# Altium schematic sheet vreg.SchDoc
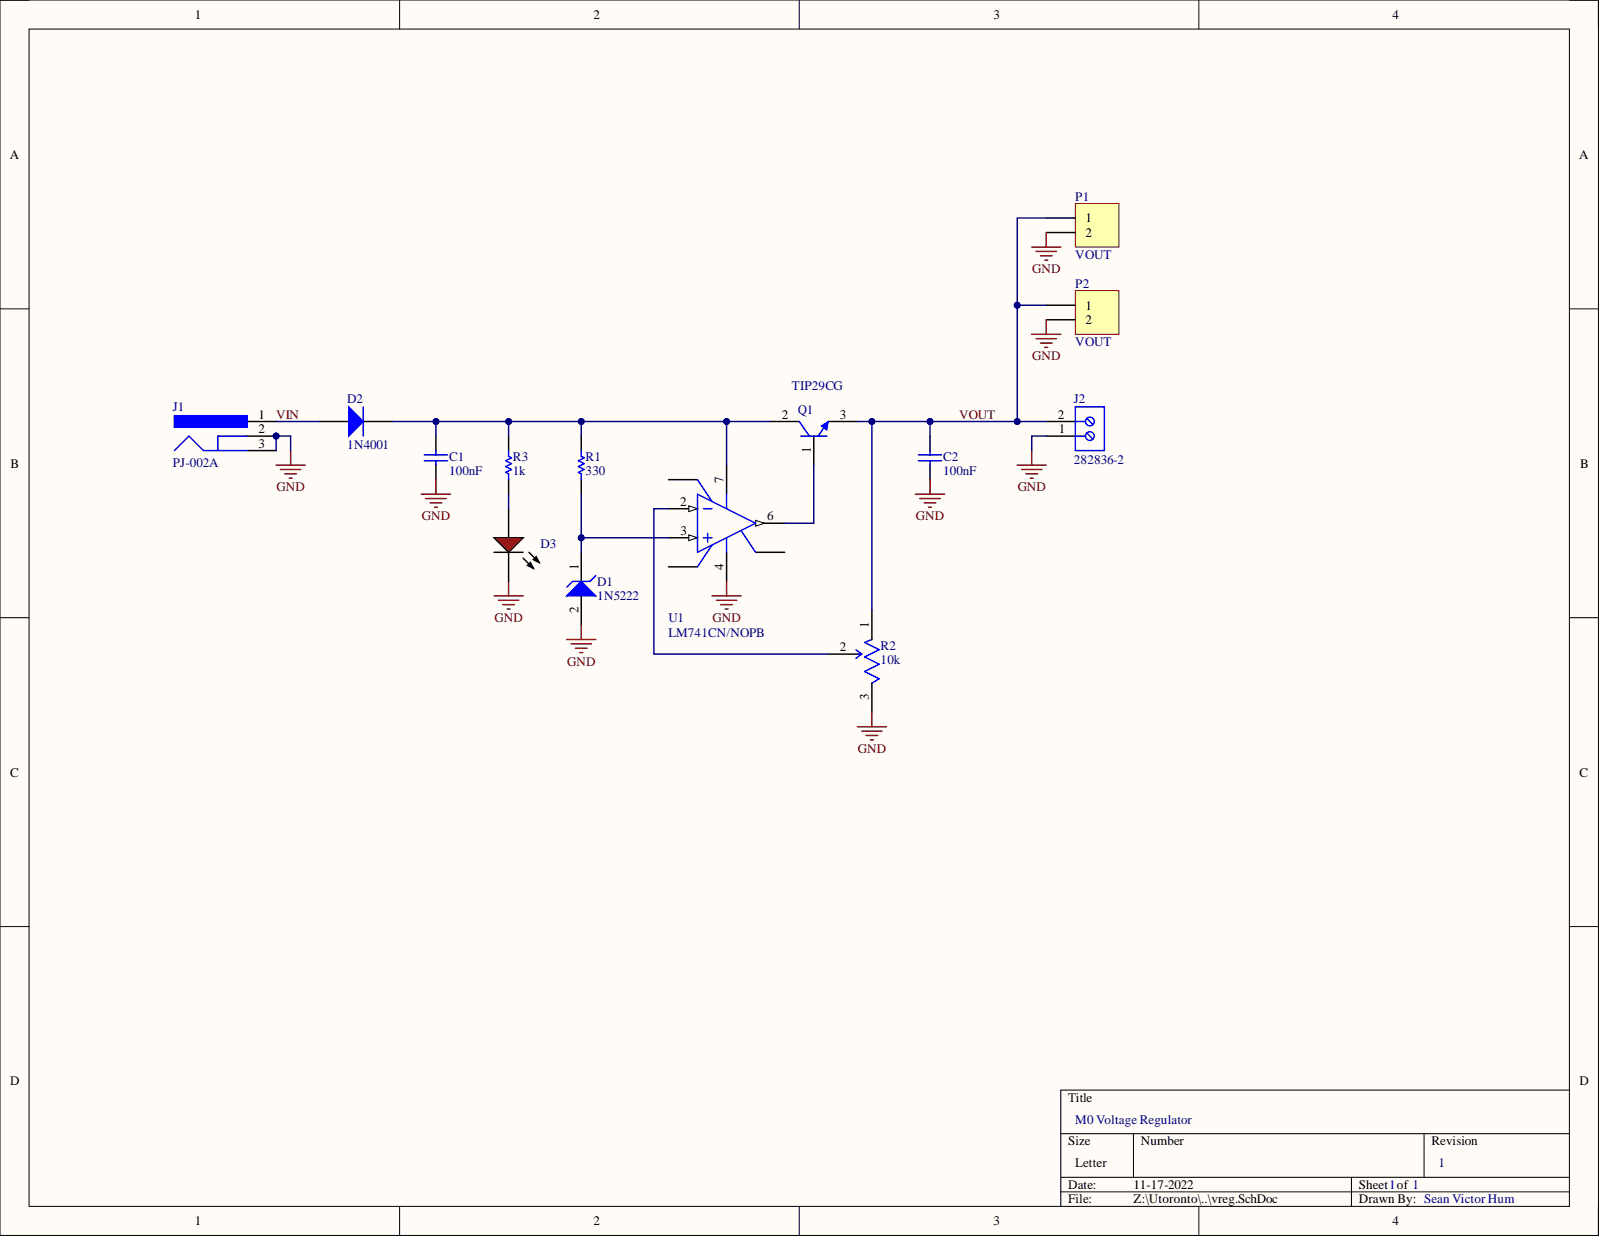
[diagram p0: vreg.SchDoc]

## Parts
C1  value 100nF  [RAD-0.1]  (hidden comment: Cap)
C2  value 100nF  [RAD-0.1]  (hidden comment: Cap)
D1  1N5222  [ONSC-SURMETIC40-2-017AA-01]
D2  1N4001  [DO-41]
D3  HLMP-3301  [AVAG-HLMP-3301-2]  (value not drawn)
J1  PJ-002A  [CUI-PJ-002A_V]
J2  282836-2  [TECO-282836-2_V]
P1  VOUT  [HDR1X2]
P2  VOUT  [HDR1X2]
Q1  TIP29CG  [ONSC-TO-220-3-221A-09]
R1  value 330  [RAD-0.1]  (hidden comment: Res1)
R2  10k  [FP-PV37W103C01B00-MFG]
R3  value 1k  [AXIAL-0.4]  (hidden comment: Res1)
U1  LM741CN/NOPB  [P0008A]

## Nets
GND: C1.2 C2.2 D1.2 D3.1 J1.2 J1.3 J2.1 P1.2 P2.2 R2.3 U1.4
VIN: D2.1 J1.1
VOUT: C2.1 J2.2 P1.1 P2.1 Q1.3 R2.1
NetC1_1: C1.1 D2.2 Q1.2 R1.2 R3.2 U1.7
NetD1_1: D1.1 R1.1 U1.3
NetD3_2: D3.2 R3.1
NetQ1_1: Q1.1 U1.6
NetR2_2: R2.2 U1.2

Not connected: U1 1 5 8

## Library data of the parts
C1 C2 = Cap: Capacitor; PartNumber: 399-4151-ND; Component Kind: Standard; LatestRevisionDate: 17-Jul-2002; LatestRevisionNote: Re-released for DXP Platform.; Library Name: Miscellaneous Devices.IntLib; PackageDescription: Radial Cap, Thru-Hole; 2 Leads; 0.3 in Pin Spacing; PackageReference: RAD-0.3; Published: 8-Jun-2000; Signal Integrity: Cap; Simulation: CAP; Publisher: Altium Limited
D1 = CMP-1060-01319-1: 5 W Zener Voltage Regulator, 2-Pin Surmetic(TM) 40, Pb-Free, Tape and Reel; Manufacturer: On Semiconductor; PartNumber: 1N5222B-ND; P Max (W): 5; VZ Typ (V): 160; Component Kind: Standard; ComponentLink1Description: Manufacturer URL; ComponentLink1URL: http://www.onsemi.com/; ComponentLink2Description: Datasheet; ComponentLink2URL: http://www.onsemi.cn/pub_link/Collateral/1N5333B-D.PDF; ComponentLink3Description: Package Specification; ComponentLink3URL: http://www.onsemi.cn/pub_link/Collateral/017AA.PDF; DatasheetVersion: Rev. 14; Library Name: Altium Content Vault; Mounting Technology: Through Hole; PackageDescription: 2-Pin, Axial Lead, Diameter 3.49 mm; PackageReference: SURMETIC40-2-017AA-01; PackageVersion: Rev. O, 09/2005; Packing: Tape and Reel; RoHS: Pb-Free
D2 = Diode 1N4001: 1 Amp General Purpose Rectifier; PartNumber: S1011EC-04-ND; Code_JEDEC: DO-204-AL; Component Kind: Standard; ComponentLink1Description: Manufacturer Link; ComponentLink1URL: http://www.fairchildsemi.com/; ComponentLink2Description: Datasheet; ComponentLink2URL: http://www.fairchildsemi.com/ds/1N%2F1N4001.pdf; DatasheetVersion: 1998; LatestRevisionDate: 17-Jul-2002; LatestRevisionNote: Re-released for DXP Platform.; Library Name: Miscellaneous Devices.IntLib; PackageDescription: Diode, Axial; Body 5.2 x 2.7 mm (LxDia. max), Lead Dia. 0.86 mm (max); PackageReference: DO-41; PackageVersion: Aug-1999; Published: 8-Jun-2000; Signal Integrity: 1N4001; Simulation: 1N4001; Publisher: Altium Limited
D3 = CMP-2000-06411-1: T-1 3/4 (5 mm) Diffused LED Lamp, 1.9 V, -40 to 100 degC, 2-Pin THD, RoHS, Bulk; Manufacturer: Avago; PartNumber: 1497-XCMDK12D-ND; Chip Material: GaP; DELETED: Compliant; Dominant Wave Length: 626nm; Emitting Color: Red; Forward Current: 25mA; Forward Voltage: 2.4V; High Brightness: No; Lens Type: ColoredDiffused; Lum Intensity: 5.4mcd; Main Category: StandardLED; Number of  Elements: 1; Peak Wavelength: 635nm; Power Dissipation: 85W; Product Depth (mm): 6.1mm; Product Height (mm): 9.19mm; Reverse Voltage: 5V; Shape Type: Circular; Test Current (It): 10mA; Type: Uni-Color; Viewing Angle: 60deg; Component Kind: Standard; Datasheet URL: http://www.avagotech.com/docs/AV02-1558EN; Datasheet Version: 03/2015; Lens Dimensions: 5.08x5.08x8.3mm; Library Name: Altium Content Vault; Luminous Intensity: 5.4mcd; Manufacturer URL: http://www.avagotech.com/; Mounting: ThroughHole; Mounting Technology: ThroughHole; Operating Temp Range: -40Cto100C; Operating Temperature Classification: Industrial; Package Description: 2-Pin Through Hole LED, Dia 5.85 mm; Package Reference: HLMP-3301; Package Type: T-13/4; Packaging: TapeandReel; Pin Count: 2; Product Length (mm): 6.1mm; Rad Hardened: No
J1 = CMP-2000-06895-1: Through Hole Right Angle DC Power Jack, 2.5 A, 2 mm Center Pin, 3 Position, -25 to 85 degC, RoHS, Bulk; Manufacturer: CUIInc.; PartNumber: CP-002A-ND; Brand: CUI; Current Rating: 2.5A; Inside Contact Diameter: 2mm; Insulation Resistance: 50MOhms; Outside Contact Diameter: 6.5mm; Voltage Rating: 24V; Component Kind: Standard; Connector Type: DCPowerJack; Contact Gender: Male; Contact Material: Copper; Contact Plating: Nickel; Datasheet URL: http://www.cui.com/product/resource/pj-002a.pdf; Datasheet Version: Rev. 1.03, 04/2016; Factory Pack Quantity: 500; Flammability Rating: UL94V-0; Housing Material: PolybutyleneTerephthalate(PBT); Library Name: Altium Content Vault; Manufacturer URL: http://www.cui.com/; Mounting Angle: Right; Mounting Style: ThroughHole; Mounting Technology: ThroughHole; Operating Temperature Range: -25Cto+85C; Package Description: 3-Pin Through Hole Device, Body 14.4 x 9 mm, Height 11 mm; Package Reference: PJ-002A; Product: Jacks; Product Category: DCPowerConnectors; RoHS: True; Termination Style: ThroughHole
J2 = CMP-1684-00017-1: Female Header, Pitch 5 mm, 1 x 2 Position, Height 10 mm, Tail Length 3.5 mm, Rohs, Bulk; Manufacturer: TE Connectivity; PartNumber: A98076-ND; 3D Model: 282836-2.pdf; Clamp Material - Plating: Brass-NickelPlated; Current: 13.5A; Dynamic Catalog: BuchananSeries; Featured Product: EurostyleTerminalBlockConnectors; Mating Orientation: HorizontalwithBoard; Number of Levels: 1; Other Names: 5ULV-02B  A98076; Pitch: 0.197"(5.00mm); Positions Per Level: 2; Product Training Modules: Wire-to-BoardEurostyleTerminalBlocks; Screw Thread: M3; Torque - Screw: 0.68Nm(6Lb-In); Voltage: 300V; Wire Gauge: 16-30AWG; Wire Termination: Screw-RisingCageClamp; Category: Connectors,Interconnects; Color: Green; Component Kind: Standard; Contact Material - Plating: Brass-TinPlated; Datasheet URL: http://www.te.com.cn/commerce/DocumentDelivery/DDEController?Action=showdoc&DocId=Catalog+Section%7F1308389_EUROSTYLE_TERMINAL_BLOCKS%7F0607%7Fpdf%7FEnglish%7FENG_CS_1308389_EUROSTYLE_TERMINAL_BLOCKS_0607.pdf%7F282836-2; Family: TerminalBlocks-WiretoBoard; Features: Interlocking(Side); Housing Material: Polyamide(PA66),Nylon6/6; Library Name: Altium Content Vault; Manufacturer URL: http://www.te.com; Mounting Type: ThroughHole; Package Description: Female Header, Pitch 5 mm, 1 x 2 Position, Height 10 mm, Tail Length 3.5 mm; Package Reference: THD; Packaging: Bulk; RoHS Information: 282836-2StatementofCompliance; Screw Material - Plating: Brass-NickelPlated; Series: Buchanan; Standard Package: 300
P1 P2 = Header 2: Header, 2-Pin; PartNumber: S1011EC-04-ND; Component Kind: Standard; LatestRevisionDate: 17-Jul-2002; LatestRevisionNote: Re-released for DXP Platform.; Library Name: Miscellaneous Connectors.IntLib; Signal Integrity: Connector; Publisher: Altium Limited
Q1 = CMP-1048-01094-1: Complementary Silicon Plastic Power Transistor, 3-Pin TO-220, Pb-Free, Tube; Manufacturer: On Semiconductor; PartNumber: TIP29CGOS-ND; fT Min (MHz): 3; IC Continuous (A): 1; Polarity: NPN; Component Kind: Standard; ComponentLink1Description: Manufacturer URL; ComponentLink1URL: http://www.onsemi.com/; ComponentLink2Description: Datasheet; ComponentLink2URL: http://www.onsemi.com/pub_link/Collateral/TIP29B-D.PDF; ComponentLink3Description: Package Specification; ComponentLink3URL: http://www.onsemi.com/pub_link/Collateral/221A-09.PDF; DatasheetVersion: Rev. 12; Library Name: Altium Content Vault; Mounting Technology: Through Hole; PackageDescription: 3-Pin Flange Mount, Vertical Straight Lead, Body 9.97 x 4.445 mm, Pitch 2.54 mm; PackageReference: TO-220-3-221A-09; PackageVersion: Rev. AG, 09/2011; Packing: Tube; RoHS: TRUE
R1 = Res1: Resistor; PartNumber: 330QBK-ND; Component Kind: Standard; LatestRevisionDate: 17-Jul-2002; LatestRevisionNote: Re-released for DXP Platform.; Library Name: Miscellaneous Devices.IntLib; PackageDescription: Axial Device, Thru-Hole; 2 Leads; 0.3 in Pin Spacing; PackageReference: AXIAL-0.3; Published: 8-Jun-2000; Signal Integrity: Res; Simulation: RESISTOR; Publisher: Altium Limited
R2 = CMP-07234-000029-1: TRIMMER 10K OHM 0.25W PC PIN TOP PV37W103C01B00; PartNumber: 490-2970-ND; Max Power Dissipation: 250mW; Number of Turns: 12; Resistance: 10kR; Temperature Coefficient: 150ppm/°C; Tolerance: 10%; Component Kind: Standard; Height: 0.264inch; Library Name: Altium Content Vault; Mount: Through Hole; Packaging: Bulk; Resistor Type: Cermet; RoHS Compliant: No; Termination: PC Pins
R3 = Res1: Resistor; PartNumber: CF14JT1K00CT-ND; Component Kind: Standard; LatestRevisionDate: 17-Jul-2002; LatestRevisionNote: Re-released for DXP Platform.; Library Name: Miscellaneous Devices.IntLib; PackageDescription: Axial Device, Thru-Hole; 2 Leads; 0.3 in Pin Spacing; PackageReference: AXIAL-0.3; Published: 8-Jun-2000; Signal Integrity: Res; Simulation: RESISTOR; Publisher: Altium Limited
U1 = CMP-0055-00162-3: Operational Amplifier, 8-pin MDIP, Pb-Free; Manufacturer: Texas Instruments; PartNumber: LM741CNNS/NOPB-ND; CompType: Amplifiers; PackageTopMarking: NSUZXYTTE#*LM*741CN; PowerWise: N; Code_JEDEC: MS-001-BA; Component Kind: Standard; ComponentLink1Description: Datasheet URL; ComponentLink1URL: http://www.ti.com/general/docs/lit/getliterature.tsp?genericPartNumber=LM741&fileType=pdf; Library Name: Altium Content Vault; Package Reference: P0008A; PackageDescription: MDIP, .365 x .250 x .130in, 8 Lead, .100in Pitch; PinCount: 8; RoHS: Y
